# Altium schematic sheet Sch6 - Fonte Switch.SchDoc("Sch6 - Fonte Switch")
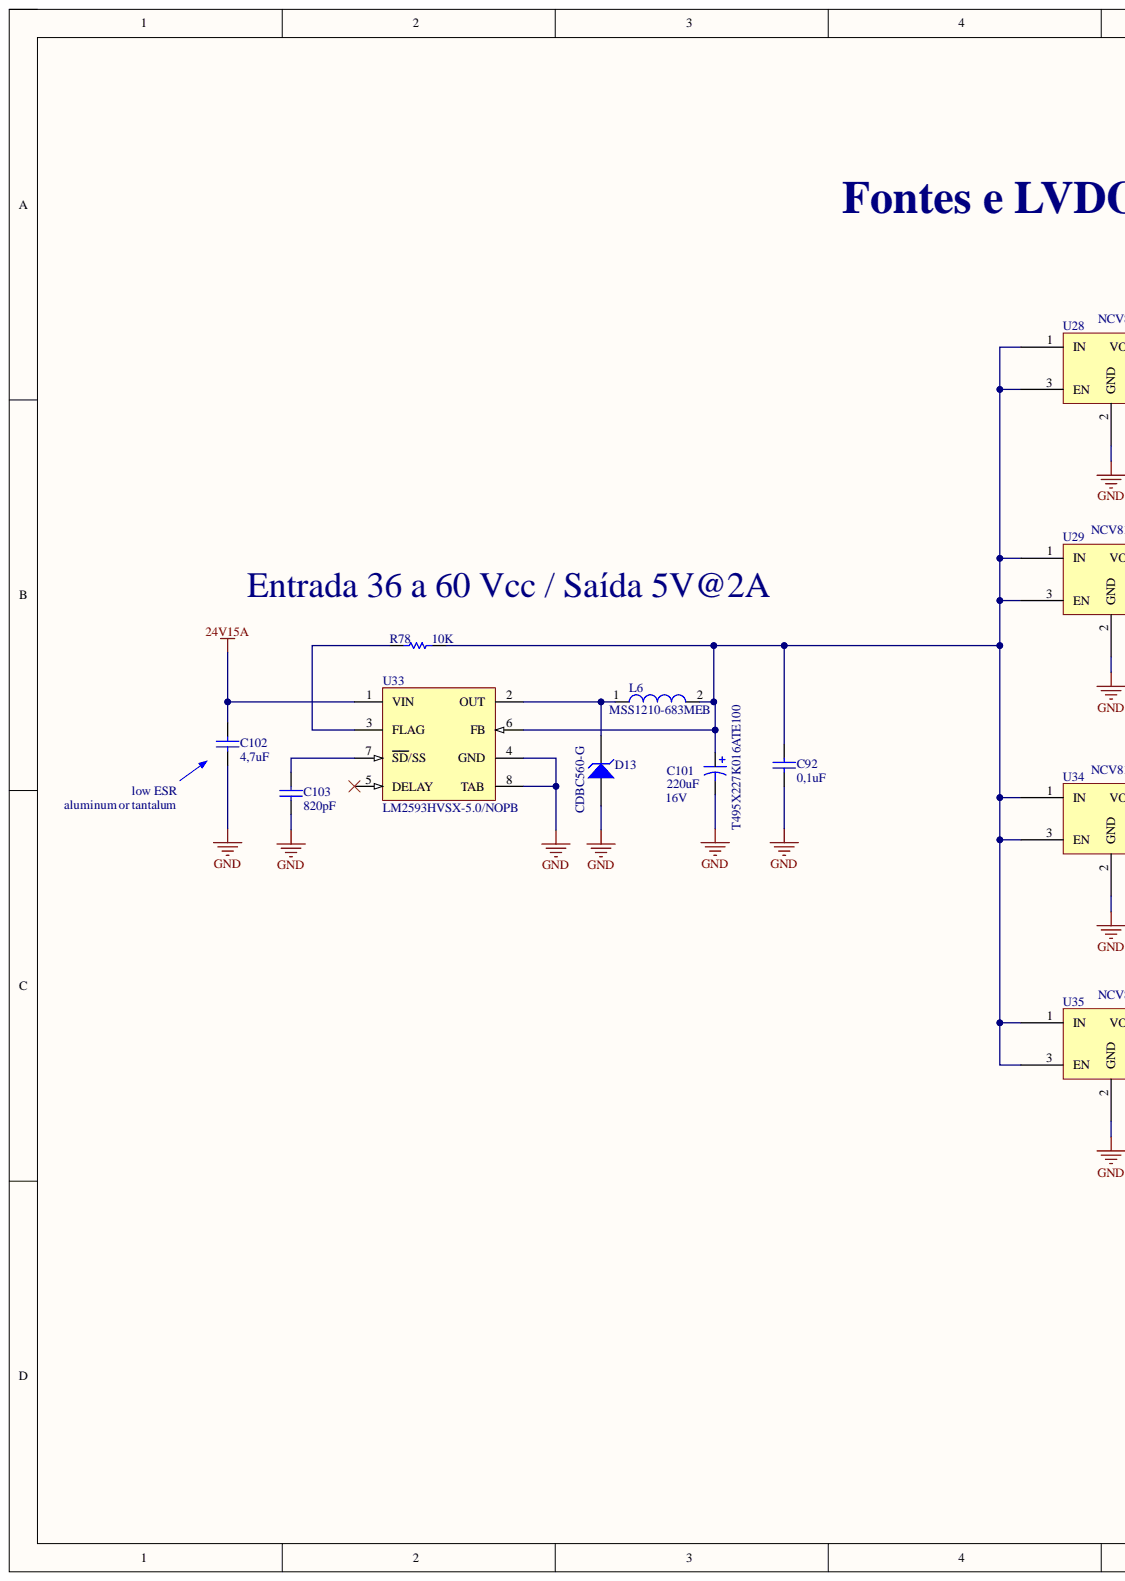
[diagram p0: Sch6 - Fonte Switch.SchDoc, left]
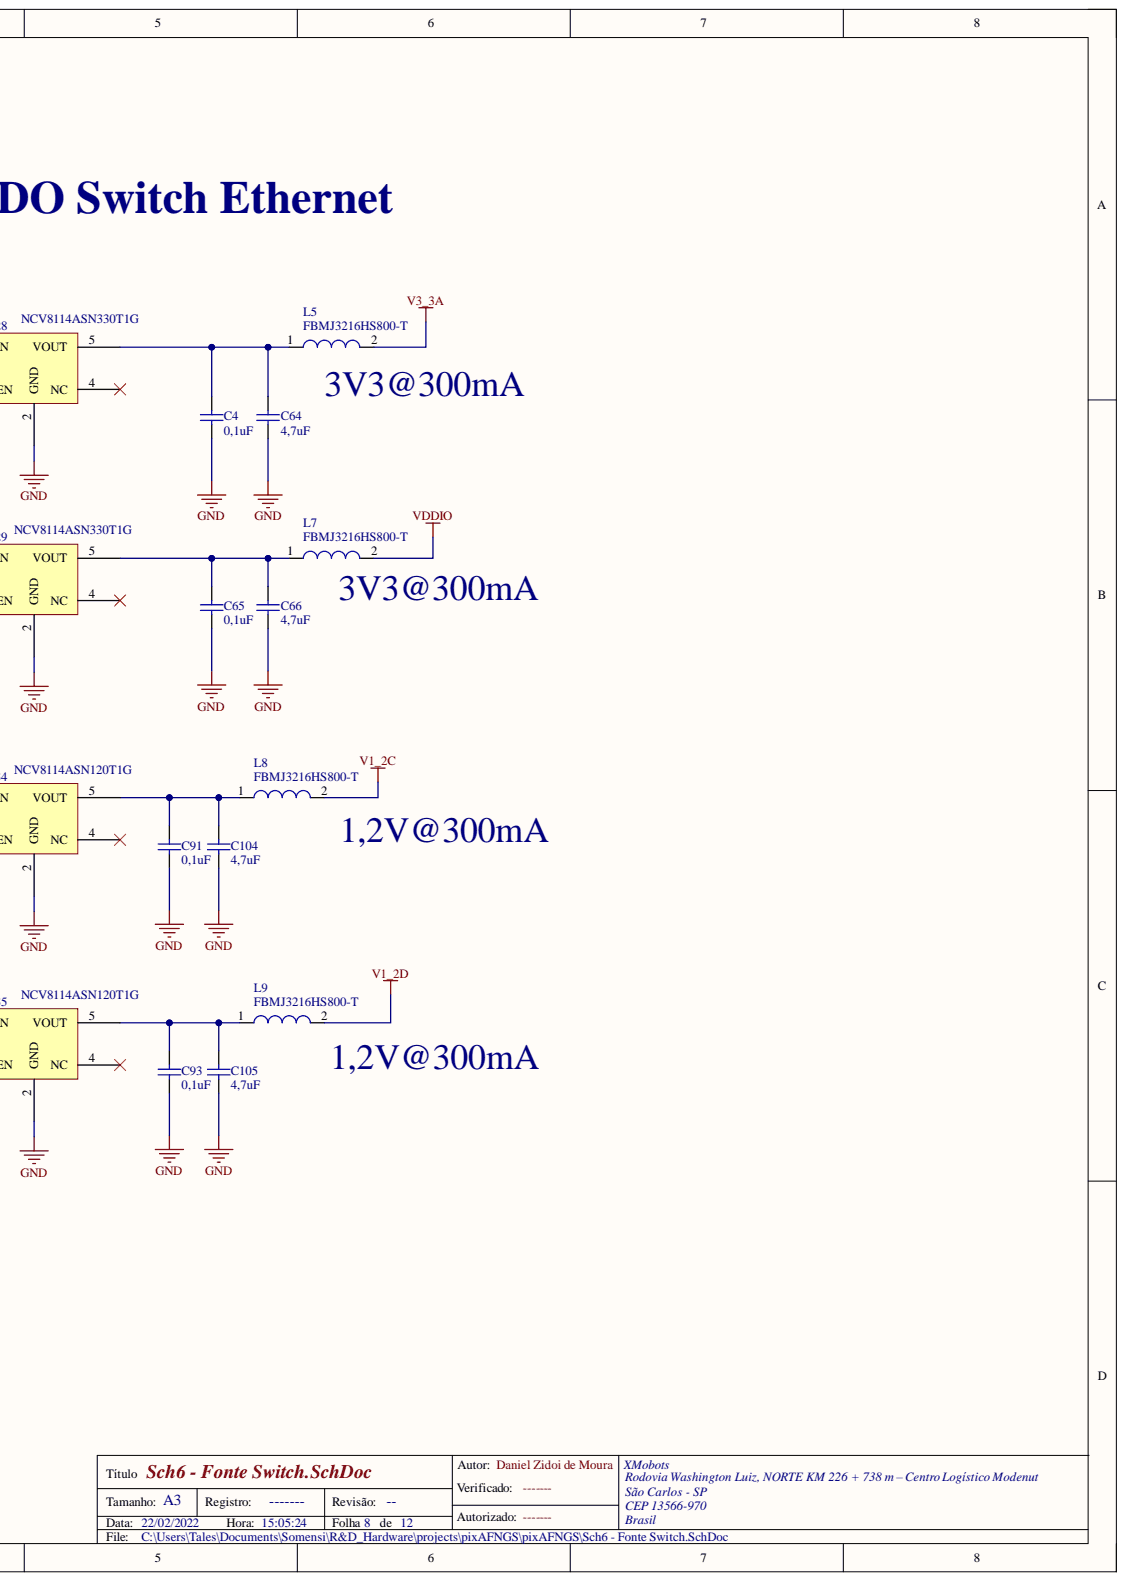
[diagram p1: Sch6 - Fonte Switch.SchDoc, right]

## Parts
C4  value 0,1uF  [0603]  (hidden comment: Cap Semi)
C64  value 4,7uF  [0603]  (hidden comment: Cap Semi)
C65  value 0,1uF  [0603]  (hidden comment: Cap Semi)
C66  value 4,7uF  [0603]  (hidden comment: Cap Semi)
C91  value 0,1uF  [0603]  (hidden comment: Cap Semi)
C92  value 0,1uF  [0603]  (hidden comment: Cap Semi)
C93  value 0,1uF  [0603]  (hidden comment: Cap Semi)
C101  T495X227K016ATE100  value 220uF  Voltage: 16V  [2917]
C102  value 4,7uF  [1210 Murata]  (hidden comment: CGA6M3X7S2A475K200AB)
C103  value 820pF  [0805]  (hidden comment: C0805C821J5GACTU)
C104  value 4,7uF  [0603]  (hidden comment: Cap Semi)
C105  value 4,7uF  [0603]  (hidden comment: Cap Semi)
D13  CDBC560-G  [DO-214AB]
L5  FBMJ3216HS800-T  [1206]
L6  MSS1210-683MEB  [MSS1210-683MEB]
L7  FBMJ3216HS800-T  [1206]
L8  FBMJ3216HS800-T  [1206]
L9  FBMJ3216HS800-T  [1206]
R78  value 10K  [0603]  (hidden comment: Res Semi)
U28  NCV8114ASN330T1G  [TSOP-5]
U29  NCV8114ASN330T1G  [TSOP-5]
U33  LM2593HVSX-5.0/NOPB  [LM2593HVSX-5.0/NOPB]
U34  NCV8114ASN120T1G  [TSOP-5]
U35  NCV8114ASN120T1G  [TSOP-5]

## Nets
24V15A: C102.2 U33.1(VIN)
GND: C4.1 C64.1 C65.1 C66.1 C91.1 C92.1 C93.1 C101.2 C102.1 C103.1 C104.1 C105.1 D13.1 U28.2(GND) U29.2(GND) U33.4(GND) U33.8(TAB) U34.2(GND) U35.2(GND)
V1_2C: L8.2
V1_2D: L9.2
V3_3A: L5.2
VDDIO: L7.2
NetC4_2: C4.2 C64.2 L5.1 U28.5(VOUT)
NetC65_2: C65.2 C66.2 L7.1 U29.5(VOUT)
NetC91_2: C91.2 C104.2 L8.1 U34.5(VOUT)
NetC92_2: C92.2 C101.1 L6.2 R78.1 U28.1(IN) U28.3(EN) U29.1(IN) U29.3(EN) U33.6(FB) U34.1(IN) U34.3(EN) U35.1(IN) U35.3(EN)
NetC93_2: C93.2 C105.2 L9.1 U35.5(VOUT)
NetC103_2: C103.2 U33.7(SD/SS)
NetD13_2: D13.2 L6.1 U33.2(OUT)
NetR78_2: R78.2 U33.3(FLAG)

Not connected: U28 4(NC); U29 4(NC); U33 5(DELAY); U34 4(NC); U35 4(NC)

## Library data of the parts
C4 C64-C66 C91-C93 C102-C105 = Cap Semi: Capacitor (Semiconductor SIM Model); Component Kind: Standard; LatestRevisionDate: 29-May-2009; LatestRevisionNote: IPC-7351 Footprint Added.; Library Name: Miscellaneous Devices.IntLib; PackageDescription: Chip Capacitor; PackageReference: C1206; Published: 8-Jun-2000; Signal Integrity: Cap Semi; Simulation: CAP; Publisher: Altium Limited
C101 = Cap Pol3: Polarized Capacitor (Surface Mount); Component Kind: Standard; LatestRevisionDate: 29-May-2009; LatestRevisionNote: IPC-7351 Footprint Added.; Library Name: Miscellaneous Devices.IntLib; PackageDescription: Chip Capacitor; PackageReference: C0805; Published: 8-Jun-2000; Signal Integrity: Cap Pol; Simulation: CAP; Publisher: Altium Limited
D13 = CDBC560-G: DIODE SCHOTTKY 60V 5A DO214AB; Component Kind: Standard; Supplier 1: Digi-Key; Supplier Part Number 1: 641-1127-1-ND; Library Name: XM_Diodes.SchLib
L5-L9 = BLM18SG221TN1D: FERRITE CHIP 220 OHM 2500MA 0603; Component Kind: Standard; Supplier 1: Digi-Key; Supplier Part Number 1: 490-5225-1-ND; Library Name: XM_Inductor.SchLib
R78 = Res Semi: Semiconductor Resistor; Component Kind: Standard; LatestRevisionDate: 17-Jul-2002; LatestRevisionNote: Re-released for DXP Platform.; Library Name: Miscellaneous Devices.IntLib; PackageReference: AXIAL-0.5; Published: 8-Jun-2000; Signal Integrity: Res Semi; Simulation: RES; Publisher: Altium Limited
U28 U29 = NCV8114ASN330T1G: Linear Voltage Regulator IC Positive Fixed 1 Output 300mA 5-TSOP; Component Kind: Standard; Library Name: XM_IC.SchLib
U33 = LM2593HVSX-5.0/NOPB: IC REG BUCK 5V 2A TO263-7; Component Kind: Standard; Library Name: XM_IC.SchLib
U34 U35 = NCV8114ASN120T1G: Linear Voltage Regulator IC Positive Fixed 1 Output 300mA 5-TSOP; Component Kind: Standard; Library Name: XM_IC.SchLib
